# Altium schematic sheet 4_Flash.SchDoc(4_Flash)
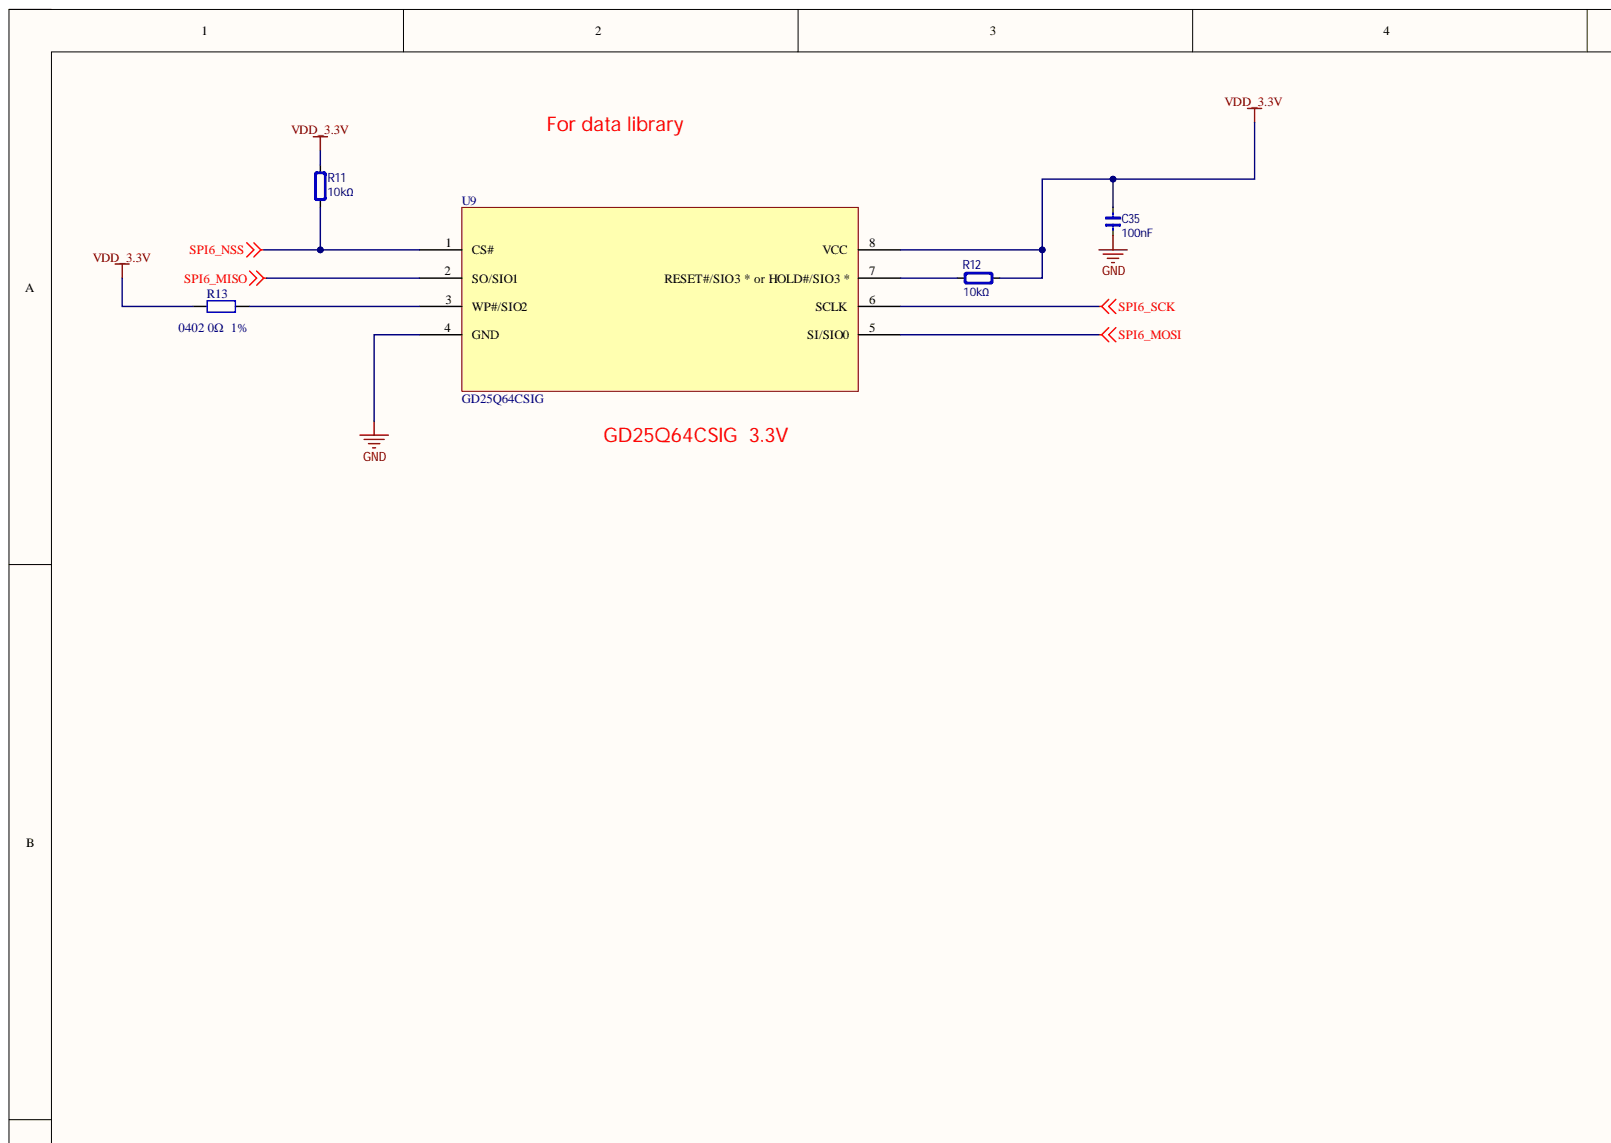
[diagram p0: 4_Flash.SchDoc, top left]
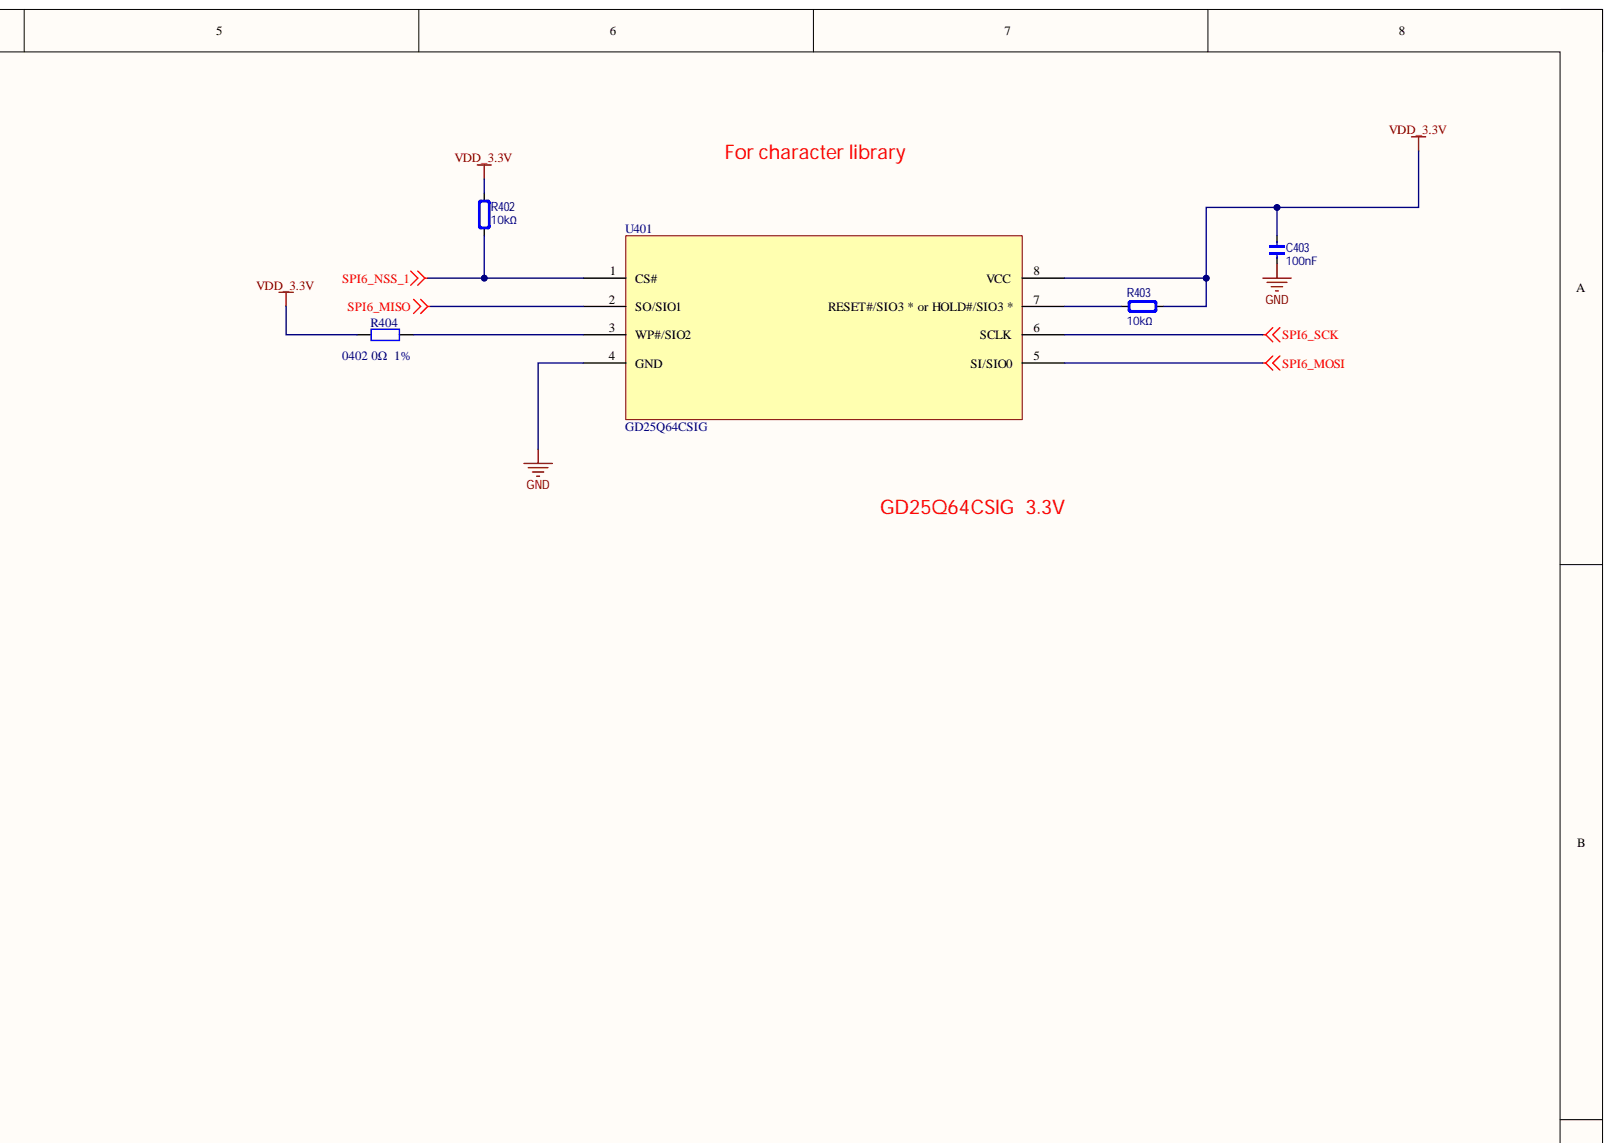
[diagram p1: 4_Flash.SchDoc, top right]
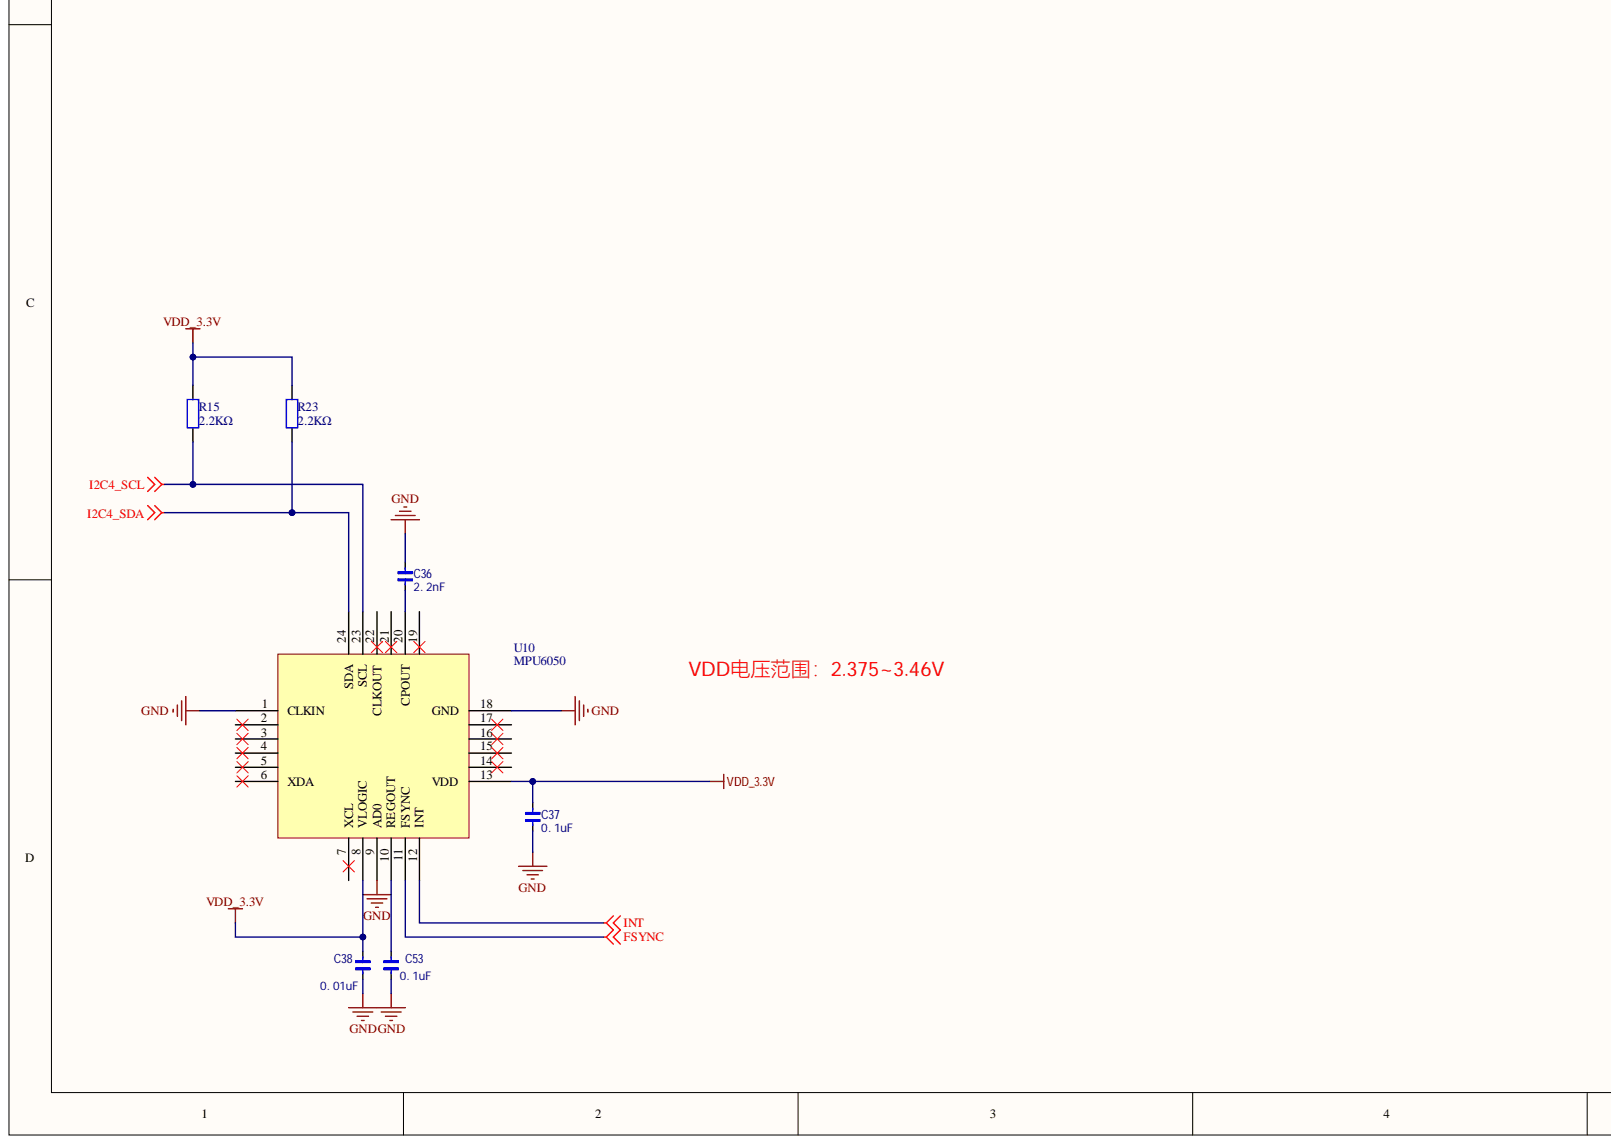
[diagram p2: 4_Flash.SchDoc, bottom left]
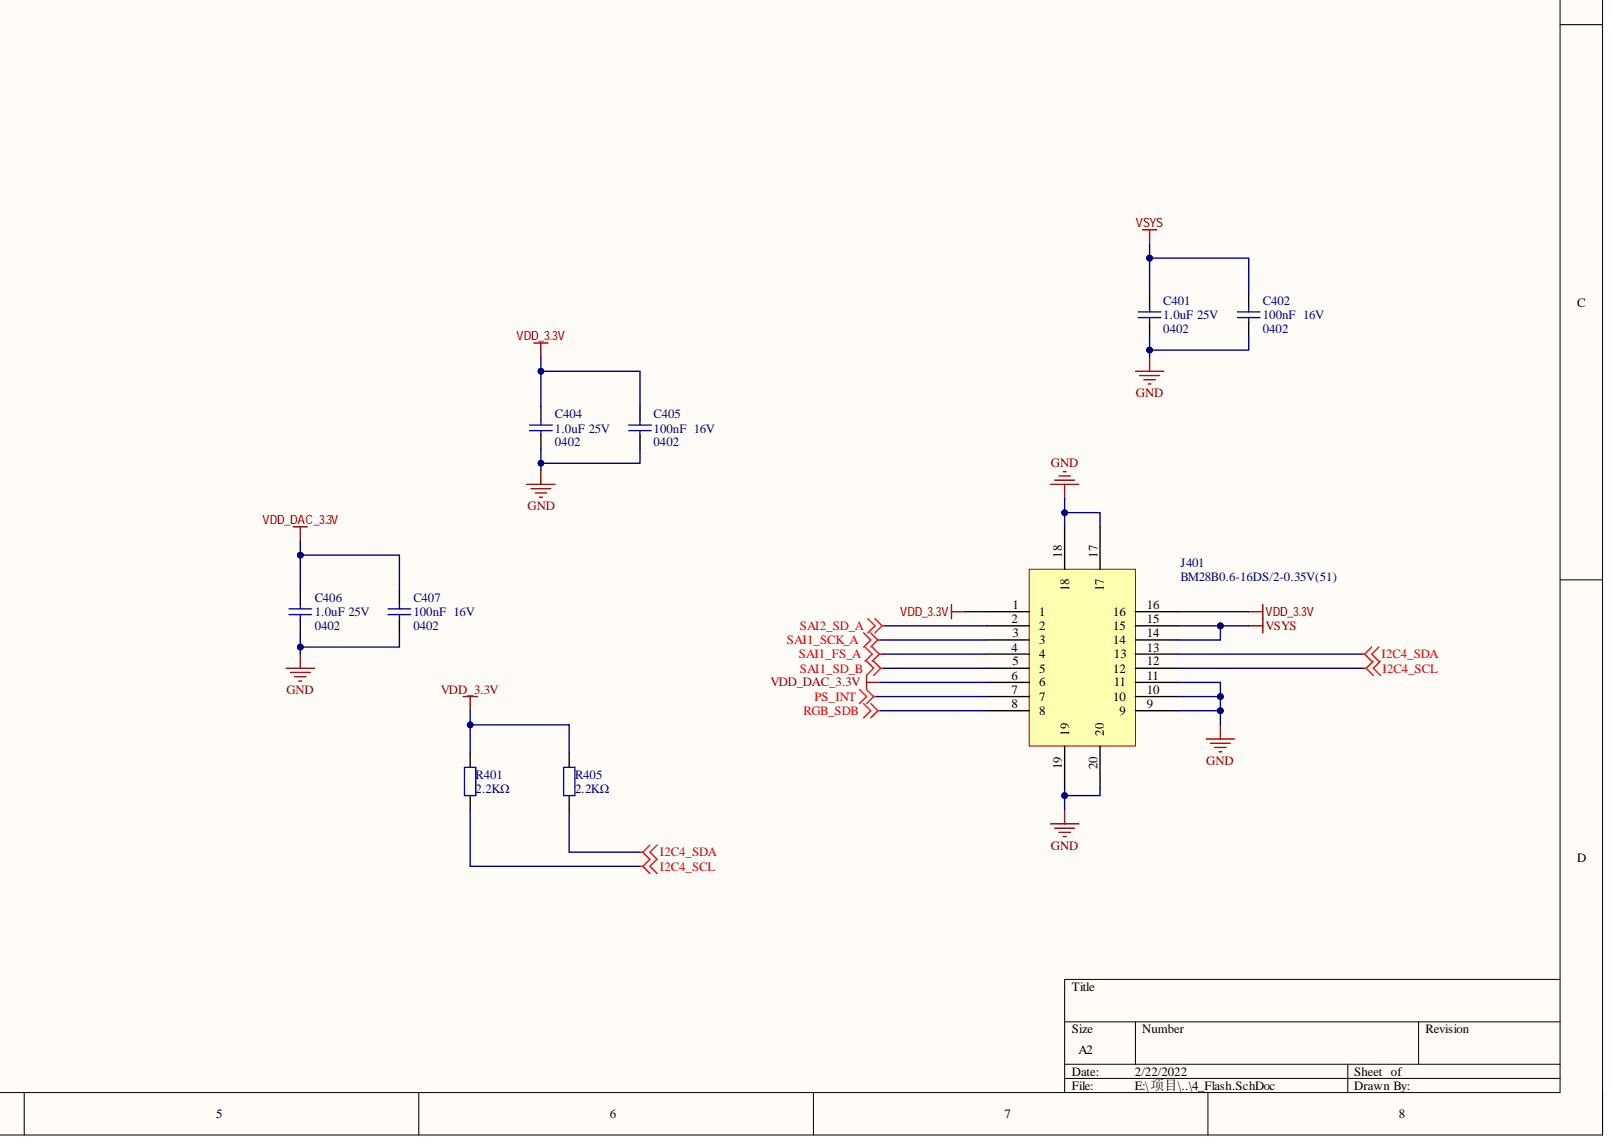
[diagram p3: 4_Flash.SchDoc, bottom right]

## Parts
C35  100nF  [0402_C]
C36  2.2nF  [0402_C]
C37  0.1uF  [0402_C]
C38  0.01uF  [0402_C]
C53  0.1uF  [0402_C]
C401  1.0uF 25V  Package: 0402  [0402_C]
C402  100nF  16V  Package: 0402  [0402_C]
C403  100nF  [0402_C]
C404  1.0uF 25V  Package: 0402  [0402_C]
C405  100nF  16V  Package: 0402  [0402_C]
C406  1.0uF 25V  Package: 0402  [0402_C]
C407  100nF  16V  Package: 0402  [0402_C]
J401  BM28B0.6-16DS/2-0.35V(51)  [CONN-SMD_BM28B0.6-16DS-2-0.35V-51]
R11  10kΩ  [0402_R]
R12  10kΩ  [0402_R]
R13  0402 0Ω  1%  Package: 0402  [0402_R]
R15  2.2KΩ  [0402_R]
R23  2.2KΩ  [0402_R]
R401  2.2KΩ  [0402_R]
R402  10kΩ  [0402_R]
R403  10kΩ  [0402_R]
R404  0402 0Ω  1%  Package: 0402  [0402_R]
R405  2.2KΩ  [0402_R]
U9  GD25Q64CSIG  [SOP-8_L5.3-W5.3-P1.27-LS8.0-BL]
U10  MPU6050  [MPU6050]
U401  GD25Q64CSIG  [SOP-8_L5.3-W5.3-P1.27-LS8.0-BL]

## Nets
FSYNC: U10.11(FSYNC)
GND: C35.1 C36.2 C37.1 C38.1 C53.1 C401.1 C402.1 C403.1 C404.1 C405.1 C406.1 C407.1 J401.9 J401.10 J401.11 J401.17 J401.18 J401.19 J401.20 U9.4(GND) U10.1(CLKIN) U10.9(AD0) U10.18(GND) U401.4(GND)
I2C4_SCL: J401.12 R15.1 R401.1 U10.23(SCL)
I2C4_SDA: J401.13 R23.1 R405.1 U10.24
INT: U10.12(INT)
PS_INT: J401.7
RGB_SDB: J401.8
SAI1_FS_A: J401.4
SAI1_SCK_A: J401.3
SAI1_SD_B: J401.5
SAI2_SD_A: J401.2
SPI6_MISO: U9.2(SO/SIO1) U401.2(SO/SIO1)
SPI6_MOSI: U9.5(SI/SIO0) U401.5(SI/SIO0)
SPI6_NSS: R11.1 U9.1(CS#)
SPI6_NSS_1: R402.1 U401.1(CS#)
SPI6_SCK: U9.6(SCLK) U401.6(SCLK)
VDD_3.3V: C35.2 C37.2 C38.2 C403.2 C404.2 C405.2 J401.1 J401.16 R11.2 R12.1 R13.1 R15.2 R23.2 R401.2 R402.2 R403.1 R404.1 R405.2 U9.8(VCC) U10.8(VLOGIC) U10.13(VDD) U401.8(VCC)
VDD_DAC_3.3V: C406.2 C407.2 J401.6
VSYS: C401.2 C402.2 J401.14 J401.15
NetC36_1: C36.1 U10.20(CPOUT)
NetC53_2: C53.2 U10.10(REGOUT)
NetR12_2: R12.2 U9.7(RESET#/SIO3_*_or_HOLD#/SIO3_*)
NetR13_2: R13.2 U9.3(WP#/SIO2)
NetR403_2: R403.2 U401.7(RESET#/SIO3_*_or_HOLD#/SIO3_*)
NetR404_2: R404.2 U401.3(WP#/SIO2)

Not connected: U10 2 3 4 5 6(XDA) 7 14 15 16 17 19 21 22(CLKOUT)

## Library data of the parts
C35-C38 C53 C403 = Cap_0402: Capacitor; Note: ±10%; Specification: X7R, ±10%, 16V; Type: 0402; Component Kind: Standard
  C35 C403: Library Name: Integrated_Library.IntLib
  C36-C38 C53: Library Name: AIDIBoxHear.SCHLIB
C401 C404 C406 = 0402 1.0uF (105) 10% 25V: 贴片电容; Component Kind: Standard; ComponentLink1Description: 供应商链接; ComponentLink1URL: http://www.szlcsc.com/product/details_53938.html; Library Name: Miscellaneous Devices LC.IntLib; Supplier: LC; SuppliersPartNumber: C52923
C402 C405 C407 = 0402 100nF (104) 10% 16V: 贴片电容; Component Kind: Standard; ComponentLink1Description: 供应商链接; ComponentLink1URL: http://www.szlcsc.com/product/details_1877.html; Library Name: Miscellaneous Devices LC.IntLib; Supplier: LC; SuppliersPartNumber: C1525
J401 = BM28B0.6-16DS/2-0.35V(51): 品　　牌： HRS(广濑)  厂家型号： BM28B0.6-16DS/2-0.35V(51)  商品编号： C424565  封装： SMD,P=0.35mm; Component Kind: Standard; Library Name: AD_LIB.SchLib
R11 R12 R402 R403 = Res_0402: Resistor; Note: ±1%; Value: ?K; Component Kind: Standard; Library Name: Integrated_Library.IntLib; Specification: ±1%; Type: 0402
R13 R404 = 0402 0Ω (0R0) 1%: 贴片电阻; Component Kind: Standard; ComponentLink1Description: 供应商链接; ComponentLink1URL: http://www.szlcsc.com/product/details_17853.html; Library Name: Miscellaneous Devices LC.IntLib; Supplier: LC; SuppliersPartNumber: C17168
R15 R23 R401 R405 = 0402 2.2KΩ (2201) 1%: 贴片电阻; Component Kind: Standard; ComponentLink1Description: 供应商链接; ComponentLink1URL: http://www.szlcsc.com/product/details_26622.html; Library Name: Miscellaneous Devices LC.IntLib; Package: 0402; Supplier: LC; SuppliersPartNumber: C25879
U9 U401 = GD25Q64CSIG: SOP-8_208mil; Component Kind: Standard; Library Name: AIDIBoxHear.SCHLIB
U10 = MPU6050: Component Kind: Standard; Library Name: AIDIBoxHear.SCHLIB
